# Altium schematic sheet STM32F405开发板v2.2_MCU.SchDoc
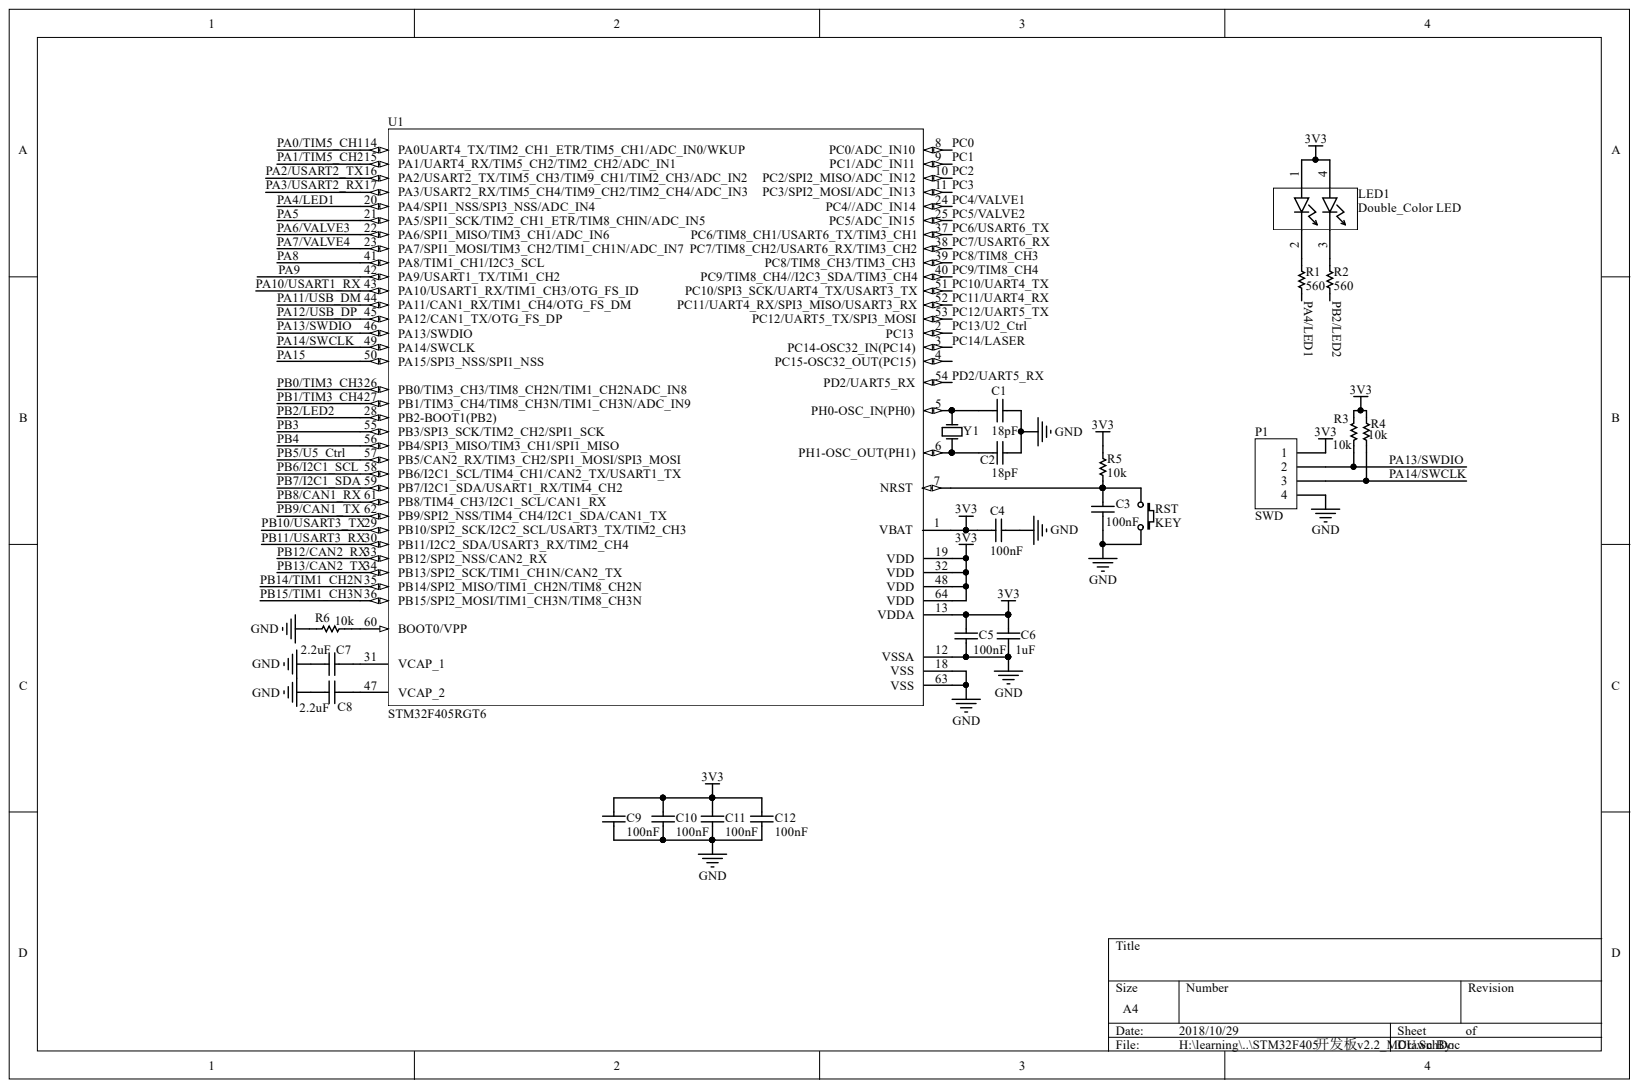
[diagram p0: STM32F405开发板v2.2_MCU.SchDoc]

## Parts
C1  18pF  [0402]
C2  18pF  [0402]
C3  100nF  [0402]
C4  100nF  [0402]
C5  100nF  [0402]
C6  1uF  [0402]
C7  2.2uF  [0402]
C8  2.2uF  [0402]
C9  100nF  [0402]
C10  100nF  [0402]
C11  100nF  [0402]
C12  100nF  [0402]
LED1  Double_Color LED  [0805LEDX2]
P1  SWD  [HDR1X4]
R1  560  [0402R]
R2  560  [0402R]
R3  10k  [0402R]
R4  10k  [0402R]
R5  10k  [0402R]
R6  10k  [0402R]
RST  KEY  [SW 3mmx4mm]
U1  STM32F405RGT6  [LQFP_64]
Y1  XTAL  [NX3225GD]  (value not drawn)

## Nets
3V3: C4.2 C5.1 C6.1 C9.1 C10.1 C11.1 C12.1 LED1.1 LED1.4 P1.1 R3.2 R4.2 R5.2 U1.1(VBAT) U1.13(VDDA) U1.19(VDD) U1.32(VDD) U1.48(VDD) U1.64(VDD)
GND: C1.2 C2.2 C3.2 C4.1 C5.2 C6.2 C7.2 C8.2 C9.2 C10.2 C11.2 C12.2 P1.4 R6.2 RST.2 U1.12(VSSA) U1.18(VSS) U1.63(VSS)
PA0/TIM5_CH1: U1.14(PA0UART4_TX/TIM2_CH1_ETR/TIM5_CH1/ADC_IN0/WKUP)
PA1/TIM5_CH2: U1.15(PA1/UART4_RX/TIM5_CH2/TIM2_CH2/ADC_IN1)
PA2/USART2_TX: U1.16(PA2/USART2_TX/TIM5_CH3/TIM9_CH1/TIM2_CH3/ADC_IN2)
PA3/USART2_RX: U1.17(PA3/USART2_RX/TIM5_CH4/TIM9_CH2/TIM2_CH4/ADC_IN3)
PA4/LED1: R1.1 U1.20(PA4/SPI1_NSS/SPI3_NSS/ADC_IN4)
PA5: U1.21(PA5/SPI1_SCK/TIM2_CH1_ETR/TIM8_CHIN/ADC_IN5)
PA6/VALVE3: U1.22(PA6/SPI1_MISO/TIM3_CH1/ADC_IN6)
PA7/VALVE4: U1.23(PA7/SPI1_MOSI/TIM3_CH2/TIM1_CH1N/ADC_IN7)
PA8: U1.41(PA8/TIM1_CH1/I2C3_SCL)
PA9: U1.42(PA9/USART1_TX/TIM1_CH2)
PA10/USART1_RX: U1.43(PA10/USART1_RX/TIM1_CH3/OTG_FS_ID)
PA11/USB_DM: U1.44(PA11/CAN1_RX/TIM1_CH4/OTG_FS_DM)
PA12/USB_DP: U1.45(PA12/CAN1_TX/OTG_FS_DP)
PA13/SWDIO: P1.2 R3.1 U1.46(PA13/SWDIO)
PA14/SWCLK: P1.3 R4.1 U1.49(PA14/SWCLK)
PA15: U1.50(PA15/SPI3_NSS/SPI1_NSS)
PB0/TIM3_CH3: U1.26(PB0/TIM3_CH3/TIM8_CH2N/TIM1_CH2NADC_IN8)
PB1/TIM3_CH4: U1.27(PB1/TIM3_CH4/TIM8_CH3N/TIM1_CH3N/ADC_IN9)
PB2/LED2: R2.1 U1.28(PB2-BOOT1(PB2))
PB3: U1.55(PB3/SPI3_SCK/TIM2_CH2/SPI1_SCK)
PB4: U1.56(PB4/SPI3_MISO/TIM3_CH1/SPI1_MISO)
PB5/U5_CTRL: U1.57(PB5/CAN2_RX/TIM3_CH2/SPI1_MOSI/SPI3_MOSI)
PB6/I2C1_SCL: U1.58(PB6/I2C1_SCL/TIM4_CH1/CAN2_TX/USART1_TX)
PB7/I2C1_SDA: U1.59(PB7/I2C1_SDA/USART1_RX/TIM4_CH2)
PB8/CAN1_RX: U1.61(PB8/TIM4_CH3/I2C1_SCL/CAN1_RX)
PB9/CAN1_TX: U1.62(PB9/SPI2_NSS/TIM4_CH4/I2C1_SDA/CAN1_TX)
PB10/USART3_TX: U1.29(PB10/SPI2_SCK/I2C2_SCL/USART3_TX/TIM2_CH3)
PB11/USART3_RX: U1.30(PB11/I2C2_SDA/USART3_RX/TIM2_CH4)
PB12/CAN2_RX: U1.33(PB12/SPI2_NSS/CAN2_RX)
PB13/CAN2_TX: U1.34(PB13/SPI2_SCK/TIM1_CH1N/CAN2_TX)
PB14/TIM1_CH2N: U1.35(PB14/SPI2_MISO/TIM1_CH2N/TIM8_CH2N)
PB15/TIM1_CH3N: U1.36(PB15/SPI2_MOSI/TIM1_CH3N/TIM8_CH3N)
PC0: U1.8(PC0/ADC_IN10)
PC1: U1.9(PC1/ADC_IN11)
PC2: U1.10(PC2/SPI2_MISO/ADC_IN12)
PC3: U1.11(PC3/SPI2_MOSI/ADC_IN13)
PC4/VALVE1: U1.24(PC4//ADC_IN14)
PC5/VALVE2: U1.25(PC5/ADC_IN15)
PC6/USART6_TX: U1.37(PC6/TIM8_CH1/USART6_TX/TIM3_CH1)
PC7/USART6_RX: U1.38(PC7/TIM8_CH2/USART6_RX/TIM3_CH2)
PC8/TIM8_CH3: U1.39(PC8/TIM8_CH3/TIM3_CH3)
PC9/TIM8_CH4: U1.40(PC9/TIM8_CH4//I2C3_SDA/TIM3_CH4)
PC10/UART4_TX: U1.51(PC10/SPI3_SCK/UART4_TX/USART3_TX)
PC11/UART4_RX: U1.52(PC11/UART4_RX/SPI3_MISO/USART3_RX)
PC12/UART5_TX: U1.53(PC12/UART5_TX/SPI3_MOSI)
PC13/U2_CTRL: U1.2(PC13)
PC14/LASER: U1.3(PC14-OSC32_IN(PC14))
PD2/UART5_RX: U1.54(PD2/UART5_RX)
NetC1_1: C1.1 U1.5(PH0-OSC_IN(PH0)) Y1.2
NetC2_1: C2.1 U1.6(PH1-OSC_OUT(PH1)) Y1.1
NetC3_1: C3.1 R5.1 RST.1 U1.7(NRST)
NetC7_1: C7.1 U1.31(VCAP_1)
NetC8_1: C8.1 U1.47(VCAP_2)
NetLED1_2: LED1.2 R1.2
NetLED1_3: LED1.3 R2.2
NetR6_1: R6.1 U1.60(BOOT0/VPP)

Not connected: U1 4(PC15-OSC32_OUT(PC15))

## Library data of the parts
C1-C12 = Cap: Capacitor; Value: 100pF; Component Kind: Standard; LatestRevisionDate: 17-Jul-2002; LatestRevisionNote: Re-released for DXP Platform.; Library Name: MyComponents_v2.4.IntLib; PackageDescription: Radial Cap, Thru-Hole; 2 Leads; 0.3 in Pin Spacing; PackageReference: RAD-0.3; Published: 8-Jun-2000; Signal Integrity: Cap; Simulation: CAP; Publisher: Altium Limited
P1 = Header 4: Header, 4-Pin; Component Kind: Standard; LatestRevisionDate: 17-Jul-2002; LatestRevisionNote: Re-released for DXP Platform.; Library Name: Miscellaneous Connectors.IntLib; Signal Integrity: Connector; Publisher: Altium Limited
R1-R6 = Res1: Resistor; Value: 1K; Component Kind: Standard; LatestRevisionDate: 17-Jul-2002; LatestRevisionNote: Re-released for DXP Platform.; Library Name: MyComponents_v2.4.IntLib; PackageDescription: Axial Device, Thru-Hole; 2 Leads; 0.3 in Pin Spacing; PackageReference: AXIAL-0.3; Published: 8-Jun-2000; Signal Integrity: Res; Simulation: RESISTOR; Publisher: Altium Limited
RST = PushButton_2p: Button; Component Kind: Standard; LatestRevisionDate: 17-Jul-2002; LatestRevisionNote: Re-released for DXP Platform.; Library Name: MyComponents_v2.4.IntLib; PackageDescription: Switch; 2 Leads; PackageReference: SPST-2; Published: 24-Mar-1999; Publisher: Altium Limited
U1 = STM32F405RGT6_detail: ARM Cortex-M4 32b MCU+FPU, 210DMIP, 1024 kB Flash, 192+4 kB RAM, 51 I/Os, 64-pin LQFP, -40 to +85癈, Tray; 16-bit Timers (IC/OC/PWM): 12x16-bit(24/24/30); 32-bit Timer (IC/OC/PWM): 2x32-bit (8/8/8); A/D Converter: 16x12-bit; D/A Converter: 2x12-bit; Internal RAM: 192kB; Operating Frequency (Processor Speed): 168MHz; Other Timer Functions: 2xWDG, RTC, 24-bit down counter; Serial Interface: 3xSPI/2xI2S/2xI2C/3xUSART(IrDa, ISO7816)/3xUART/1xUSB OTG FS/HS, 2xCAN/ SDIO; Component Kind: Standard; ComponentLink1Description: Manufacturer URL; ComponentLink1URL: http://www.st.com/; ComponentLink2Description: STM32F405/7 Datasheet; ComponentLink2URL: http://www.st.com/internet/com/TECHNICAL_RESOURCES/TECHNICAL_LITERATURE/DATASHEET/DM00037051.pdf; ComponentLink3Description: STM32F4 Web Page; ComponentLink3URL: http://www.st.com/internet/mcu/subclass/1521.jsp; DatasheetVersion: rev2, Jan-2012; Library Name: MyComponents_v2.4.IntLib; PackageDescription: 64-Pin Low Profile Quad Flat Package, 10x10 mm Body, 0.5 mm Pitch; PackageReference: TQFP64; PackageVersion: 2012
Y1 = XTAL: Crystal Oscillator; Component Kind: Standard; LatestRevisionDate: 17-Jul-2002; LatestRevisionNote: Re-released for DXP Platform.; Library Name: MyComponents_v2.4.IntLib; PackageDescription: Crystal, Thru-Hole; 2 Leads; Body 3.1 x 8.2 mm (Dia.xH); PackageReference: R38; Published: 8-Jun-2000; Simulation: XTAL; Publisher: Altium Limited
LED1 = Double_Color LED: Component Kind: Standard; Library Name: MyComponents_v2.4.IntLib
